# Altium schematic sheet power.SchDoc
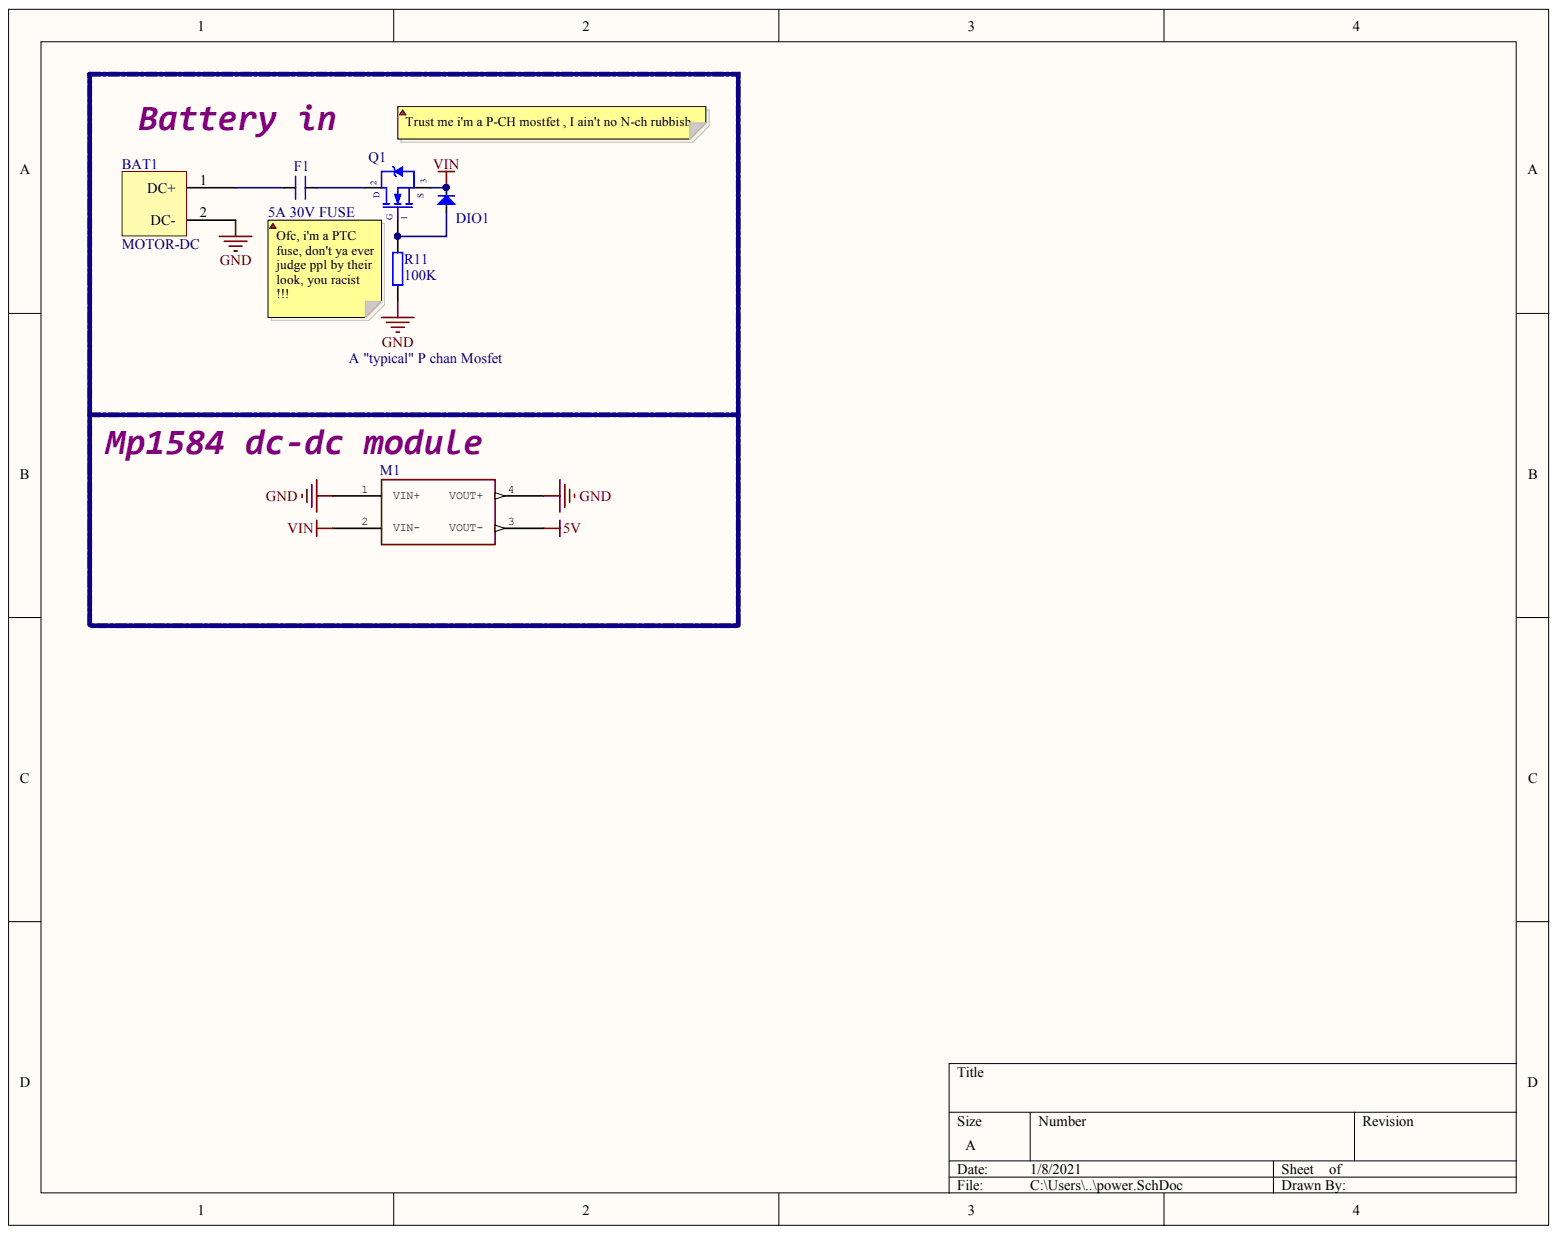
[diagram p0: power.SchDoc]

## Parts
BAT1  MOTOR-DC  [CON2-5.08DC]
DIO1  Diode SMD  [DIO-SMD-123]  (value not drawn)
F1  5A 30V FUSE  [CN2]
M1  MP1584-dc-brick-pcb Step down Input: 4.5V - 28V Ouput 0.8V - 25V max. 3A Cheap on ebay  [DC-BRICK]  (value not drawn)
Q1  A "typical" P chan Mosfet  [QTO-220]
R11  value 100K  [SMT0603]  (hidden comment: Resistor)

## Nets
5V: M1.3(VOUT-)
GND: BAT1.2 M1.1(VIN+) M1.4(VOUT+) R11.1
VIN: DIO1.2 M1.2(VIN-) Q1.3
NetBAT1_1: BAT1.1 F1.1
NetDIO1_1: DIO1.1 Q1.1 R11.2
NetF1_2: F1.2 Q1.2

## Library data of the parts
DIO1 = Diode SMD: Default Diode; Code - JEDEC: DO-214-AB; Value: 1N5007; Component Kind: Standard; Library Name: My Library.SchLib; Published: 8-Jun-2000; Revision: July-2002: Re-released for DXP Platform.; Publisher: Altium Limited
F1 = CN2: Cap np 104 => 105pF; Value: 0.1uF; Component Kind: Standard; Library Name: My Library.SchLib
M1 = MP1584: Component Kind: Standard; Library Name: MP1584-dc-brick.SCHLIB
Q1 = IRF840: MOSFET N - CHANNEL 500V - 0.75 - 8A - TO-220; Value: IRF840; Component Kind: Standard; Library Name: My Library.SchLib
R11 = RES-SM-0603-100K: RES SM 0603 100K; Component Kind: Standard; Library Name: electronpowered.SchLib; Supplier 1: Farnell; Supplier 2: Digi-Key; Supplier Part Number 1: 1344361; Supplier Part Number 2: 541-100KHCT-ND
BAT1 = MOTOR-DC: Component Kind: Standard; Library Name: RASHBERRY_EXTERN.SchLib
